# Altium schematic sheet Power.SchDoc
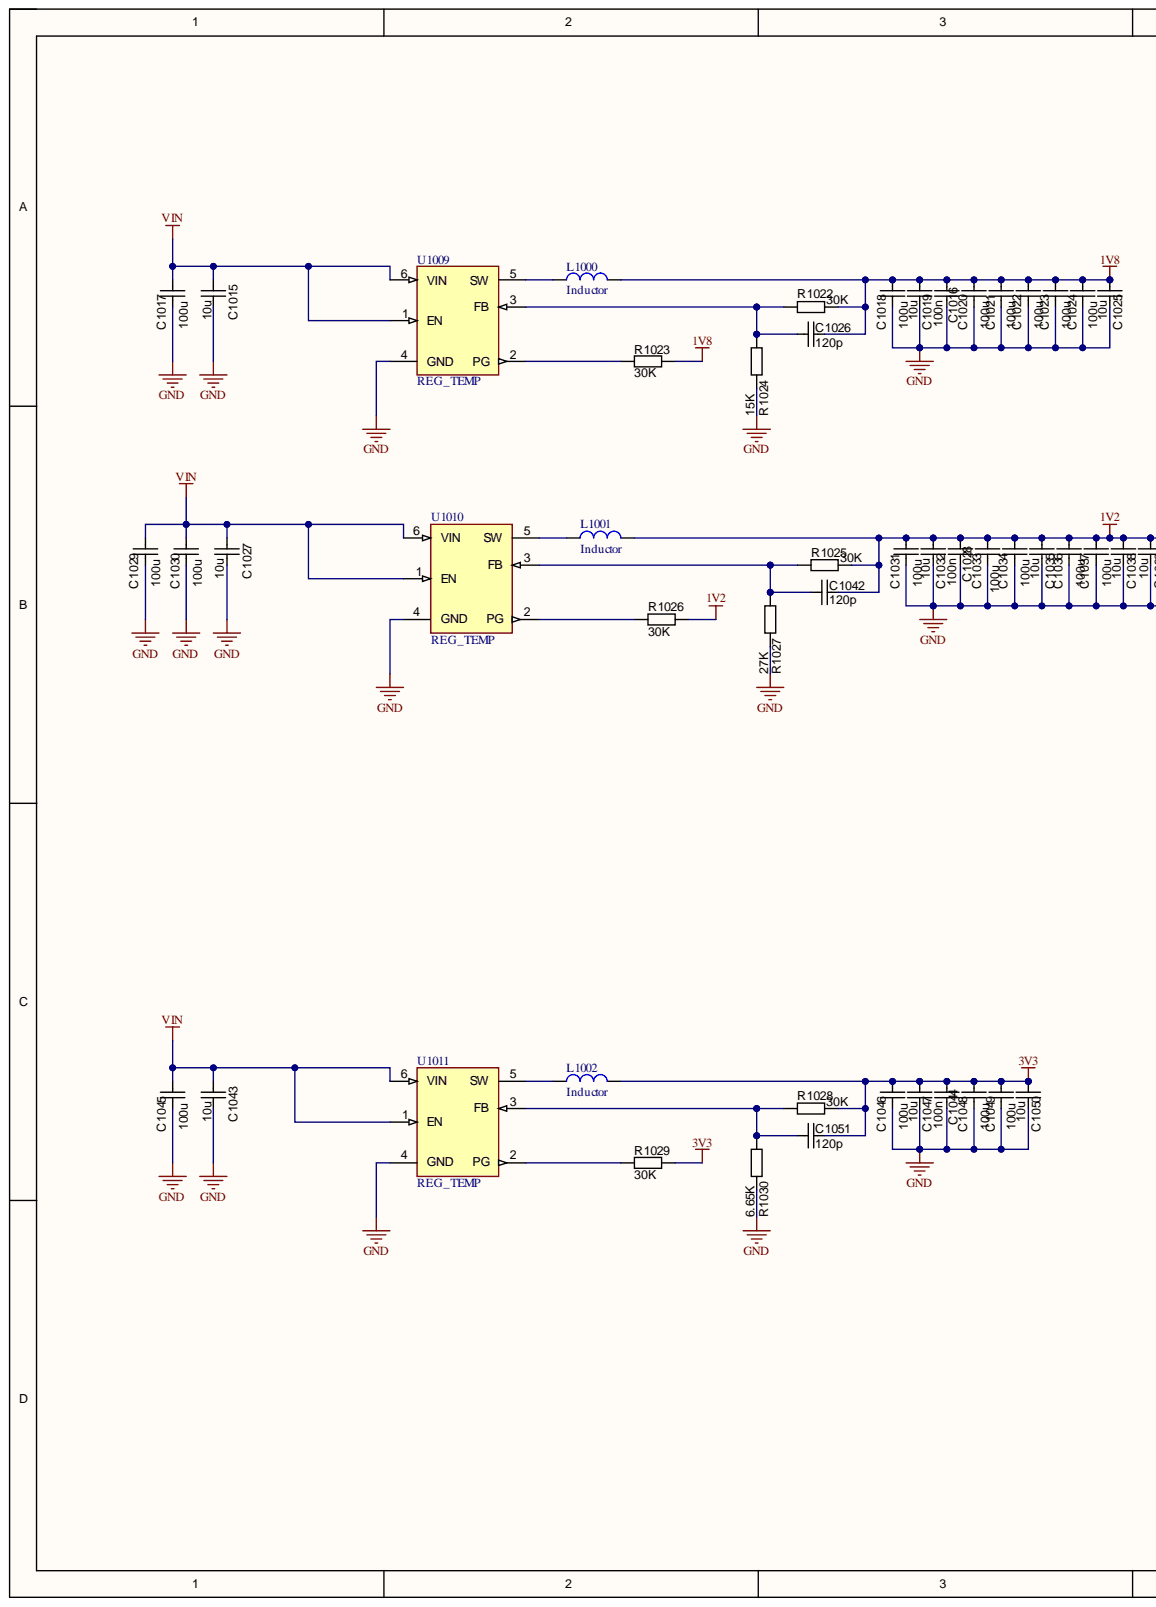
[diagram p0: Power.SchDoc, left]
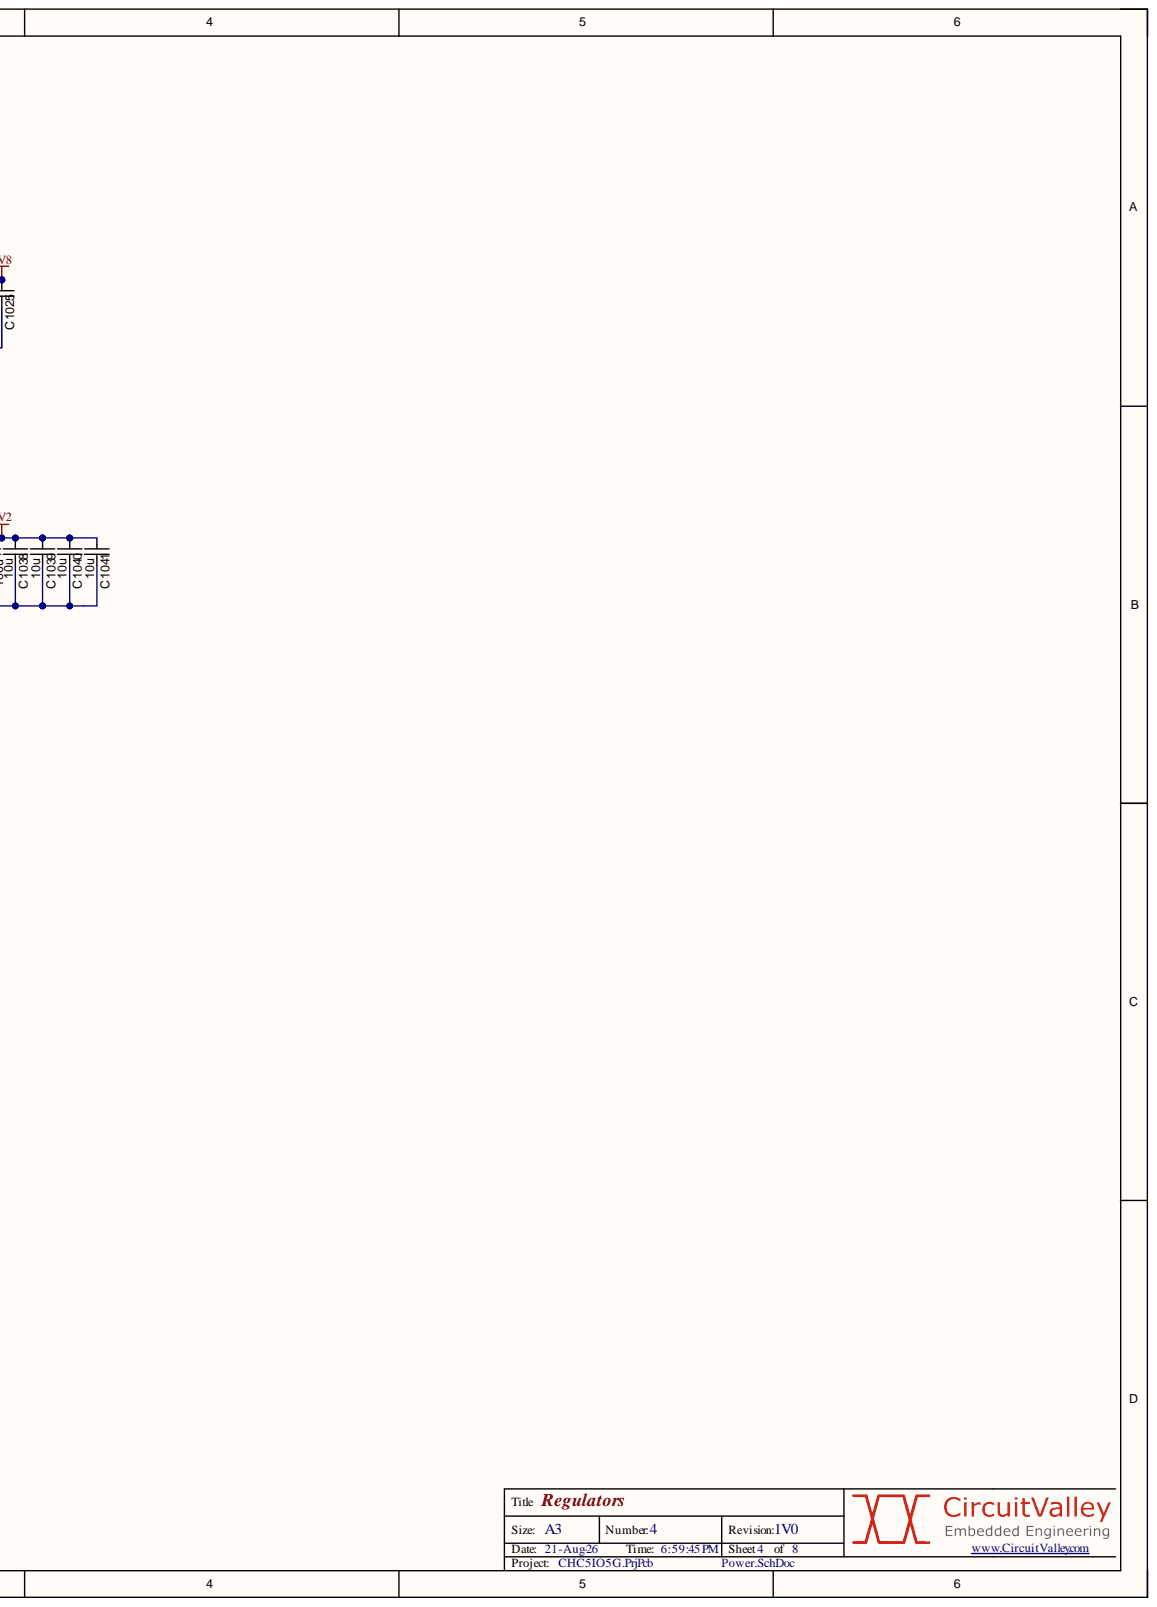
[diagram p1: Power.SchDoc, right]

## Parts
C1015  value 10u  [CAPC1608N]  (hidden comment: approved sub OK)
C1016  100n  [CAPC1005N]
C1017  value 100u  [CAPC2012N]  (hidden comment: approved sub OK)
C1018  value 100u  [CAPC2012N]  (hidden comment: approved sub OK)
C1019  value 10u  [CAPC1608N]  (hidden comment: approved sub OK)
C1020  value 100u  [CAPC2012N]  (hidden comment: approved sub OK)
C1021  value 100u  [CAPC2012N]  (hidden comment: approved sub OK)
C1022  value 100u  [CAPC2012N]  (hidden comment: approved sub OK)
C1023  value 100u  [CAPC2012N]  (hidden comment: approved sub OK)
C1024  value 100u  [CAPC2012N]  (hidden comment: approved sub OK)
C1025  value 10u  [CAPC1608N]  (hidden comment: approved sub OK)
C1026  120p  [CAPC1005N]
C1027  value 10u  [CAPC1608N]  (hidden comment: approved sub OK)
C1028  100n  [CAPC1005N]
C1029  value 100u  [CAPC2012N]  (hidden comment: approved sub OK)
C1030  value 100u  [CAPC2012N]  (hidden comment: approved sub OK)
C1031  value 100u  [CAPC2012N]  (hidden comment: approved sub OK)
C1032  value 10u  [CAPC1608N]  (hidden comment: approved sub OK)
C1033  value 100u  [CAPC2012N]  (hidden comment: approved sub OK)
C1034  value 100u  [CAPC2012N]  (hidden comment: approved sub OK)
C1035  value 10u  [CAPC1608N]  (hidden comment: approved sub OK)
C1036  value 100u  [CAPC2012N]  (hidden comment: approved sub OK)
C1037  value 100u  [CAPC2012N]  (hidden comment: approved sub OK)
C1038  value 10u  [CAPC1608N]  (hidden comment: approved sub OK)
C1039  value 10u  [CAPC1608N]  (hidden comment: approved sub OK)
C1040  value 10u  [CAPC1608N]  (hidden comment: approved sub OK)
C1041  value 10u  [CAPC1608N]  (hidden comment: approved sub OK)
C1042  120p  [CAPC1005N]
C1043  value 10u  [CAPC1608N]  (hidden comment: approved sub OK)
C1044  100n  [CAPC1005N]
C1045  value 100u  [CAPC2012N]  (hidden comment: approved sub OK)
C1046  value 100u  [CAPC2012N]  (hidden comment: approved sub OK)
C1047  value 10u  [CAPC1608N]  (hidden comment: approved sub OK)
C1048  value 100u  [CAPC2012N]  (hidden comment: approved sub OK)
C1049  value 100u  [CAPC2012N]  (hidden comment: approved sub OK)
C1050  value 10u  [CAPC1608N]  (hidden comment: approved sub OK)
C1051  120p  [CAPC1005N]
L1000  Inductor  [TFM201610ALC-R47MTAA]
L1001  Inductor  [TFM201610ALC-R47MTAA]
L1002  Inductor  [TFM201610ALC-R47MTAA]
R1022  value 30K  [RESC1005N]  (hidden comment: Thick Film)
R1023  value 30K  [RESC1005N]  (hidden comment: Thick Film)
R1024  value 15K  [RESC1005N]  (hidden comment: Thick Film)
R1025  value 30K  [RESC1005N]  (hidden comment: Thick Film)
R1026  value 30K  [RESC1005N]  (hidden comment: Thick Film)
R1027  value 27K  [RESC1005N]  (hidden comment: Thick Film)
R1028  value 30K  [RESC1005N]  (hidden comment: Thick Film)
R1029  value 30K  [RESC1005N]  (hidden comment: Thick Film)
R1030  value 6.65K  [RESC1005N]  (hidden comment: Thick Film)
U1009  REG_TEMP  [VSON-6]
U1010  REG_TEMP  [VSON-6]
U1011  REG_TEMP  [VSON-6]

## Nets
1V2: C1028.2 C1031.1 C1032.1 C1033.1 C1034.1 C1035.1 C1036.1 C1037.1 C1038.1 C1039.1 C1040.1 C1041.1 C1042.2 L1001.2 R1025.2 R1026.1
1V8: C1016.2 C1018.1 C1019.1 C1020.1 C1021.1 C1022.1 C1023.1 C1024.1 C1025.1 C1026.2 L1000.2 R1022.2 R1023.1
3V3: C1044.2 C1046.1 C1047.1 C1048.1 C1049.1 C1050.1 C1051.2 L1002.2 R1028.2 R1029.1
GND: C1015.2 C1016.1 C1017.2 C1018.2 C1019.2 C1020.2 C1021.2 C1022.2 C1023.2 C1024.2 C1025.2 C1027.2 C1028.1 C1029.2 C1030.2 C1031.2 C1032.2 C1033.2 C1034.2 C1035.2 C1036.2 C1037.2 C1038.2 C1039.2 C1040.2 C1041.2 C1043.2 C1044.1 C1045.2 C1046.2 C1047.2 C1048.2 C1049.2 C1050.2 R1024.2 R1027.2 R1030.2 U1009.4(GND) U1010.4(GND) U1011.4(GND)
VIN: C1015.1 C1017.1 C1027.1 C1029.1 C1030.1 C1043.1 C1045.1 U1009.1(EN) U1009.6(VIN) U1010.1(EN) U1010.6(VIN) U1011.1(EN) U1011.6(VIN)
NetC1026_1: C1026.1 R1022.1 R1024.1 U1009.3(FB)
NetC1042_1: C1042.1 R1025.1 R1027.1 U1010.3(FB)
NetC1051_1: C1051.1 R1028.1 R1030.1 U1011.3(FB)
NetL1000_1: L1000.1 U1009.5(SW)
NetL1001_1: L1001.1 U1010.5(SW)
NetL1002_1: L1002.1 U1011.5(SW)
NetR1023_2: R1023.2 U1009.2(PG)
NetR1026_2: R1026.2 U1010.2(PG)
NetR1029_2: R1029.2 U1011.2(PG)

## Library data of the parts
C1015 C1019 C1025 C1027 C1032 C1035 C1038-C1041 C1043 C1047 C1050 = CAPC_560p_0603_5%: Capacitor SMD Cer 560pF 5% 50V NP0 0603; Manufacturer 1: AVX Corporation; Manufacturer Part Number 1: 06035A561JAT2A; Capacitance: 470pF; Material: ceramic; Ratings: 50V; NP0; Applications: General Purpose; Component Kind: Standard; ComponentLink1Description: http://www.avx.com/docs/Catalogs/ccog.pdf; ComponentLink1URL: http://www.avx.com/docs/Catalogs/ccog.pdf; Height - Seated (Max): -; Lead Spacing: -; Lead Style: -; Library Name: PBL - Capacitors.SCHLIB; Mounting Type: Surface Mount, MLCC; Operating Temperature: -55°C ~ 125°C; Package / Case: 0603 (1608 metric); Size / Dimension: 0.063" L x 0.032" W (1.60mm x 0.81mm); Supplier 1: Digi-Key; Supplier Part Number 1: 478-1184-1-ND; Thickness (Max): 0.035" (0.90mm); Voltage - Rated: 50V
C1016 C1026 C1028 C1042 C1044 C1051 = CAPC_10n_0402_16V_10%: Capacitor SMD Cer 10nF 10% 16V X7R 0402; Manufacturer 1: AVX Corporation; Manufacturer Part Number 1: 0402YC103KAT2A; Ratings: 16V; X7R; Component Kind: Standard; ComponentLink1Description: http://www.avx.com/docs/Catalogs/cx7r.pdf; ComponentLink1URL: http://www.avx.com/docs/Catalogs/cx7r.pdf; ComponentLink2Description: http://www.yageo.com/documents/recent/UPY-GPHC_X7R_6.3V-to-50V_8.pdf; ComponentLink2URL: http://www.yageo.com/documents/recent/UPY-GPHC_X7R_6.3V-to-50V_8.pdf; Library Name: PBL - Capacitors.SCHLIB; Manufacturer 2: Yageo; Manufacturer Part Number 2: CC0402KRX7R7BB103; Operating Temperature: -55°C ~ 125°C; Package / Case: 0402 (1005 Metric); Size / Dimension: 0.039" L x 0.020" W (1.00mm x 0.50mm); Supplier 1: Digi-Key; Supplier 2: Digi-Key; Supplier Part Number 1: 478-1114-1-ND; Supplier Part Number 2: 311-1042-1-ND; Tolerance: ±10%
  C1016 C1028 C1044: Value: 100n
  C1026 C1042 C1051: Value: 120p
C1017 C1018 C1020-C1024 C1029-C1031 C1033 C1034 C1036 C1037 C1045 C1046 C1048 C1049 = CAPC_1u_0805: Capacitor SMD Cer 1uF 10% 16V X7R 0805; Manufacturer 1: TDK Corporation; Manufacturer Part Number 1: C2012X7R1C105K/1.25; Material: ceramic; Ratings: 16V; X7R; Component Kind: Standard; Library Name: PBL - Capacitors.SCHLIB; Package / Case: 0805 (2012 metric); Supplier 1: Digi-Key; Supplier Part Number 1: 445-1358-1-ND; Tolerance: ±10%
L1000-L1002 = inductor_smd: Component Kind: Standard; Library Name: Inductor.SchLib
R1022-R1030 = RES_3K3_0402_5%: Resistor SMD chip 3.3k Ohm 0.063W 5% 0402; Manufacturer 1: Yageo; Manufacturer Part Number 1: RC0402JR-073K3L; Ratings: 1/16 W; Component Kind: Standard; ComponentLink1Description: http://www.yageo.com/documents/recent/PYu-RC0402_51_RoHS_L_6.pdf; ComponentLink1URL: http://www.yageo.com/documents/recent/PYu-RC0402_51_RoHS_L_6.pdf; Library Name: PBL - Resistors.SCHLIB; Material: Thick Film; Package / Case: 0402 (1005 metric); Supplier 1: Digi-Key; Supplier Part Number 1: 311-3.3KJRCT-ND; Tolerance: ±5%
U1009-U1011 = TPS6282x: Component Kind: Standard; Library Name: cvlib.SchLib
